# Altium schematic sheet MCU.SchDoc(MCU)
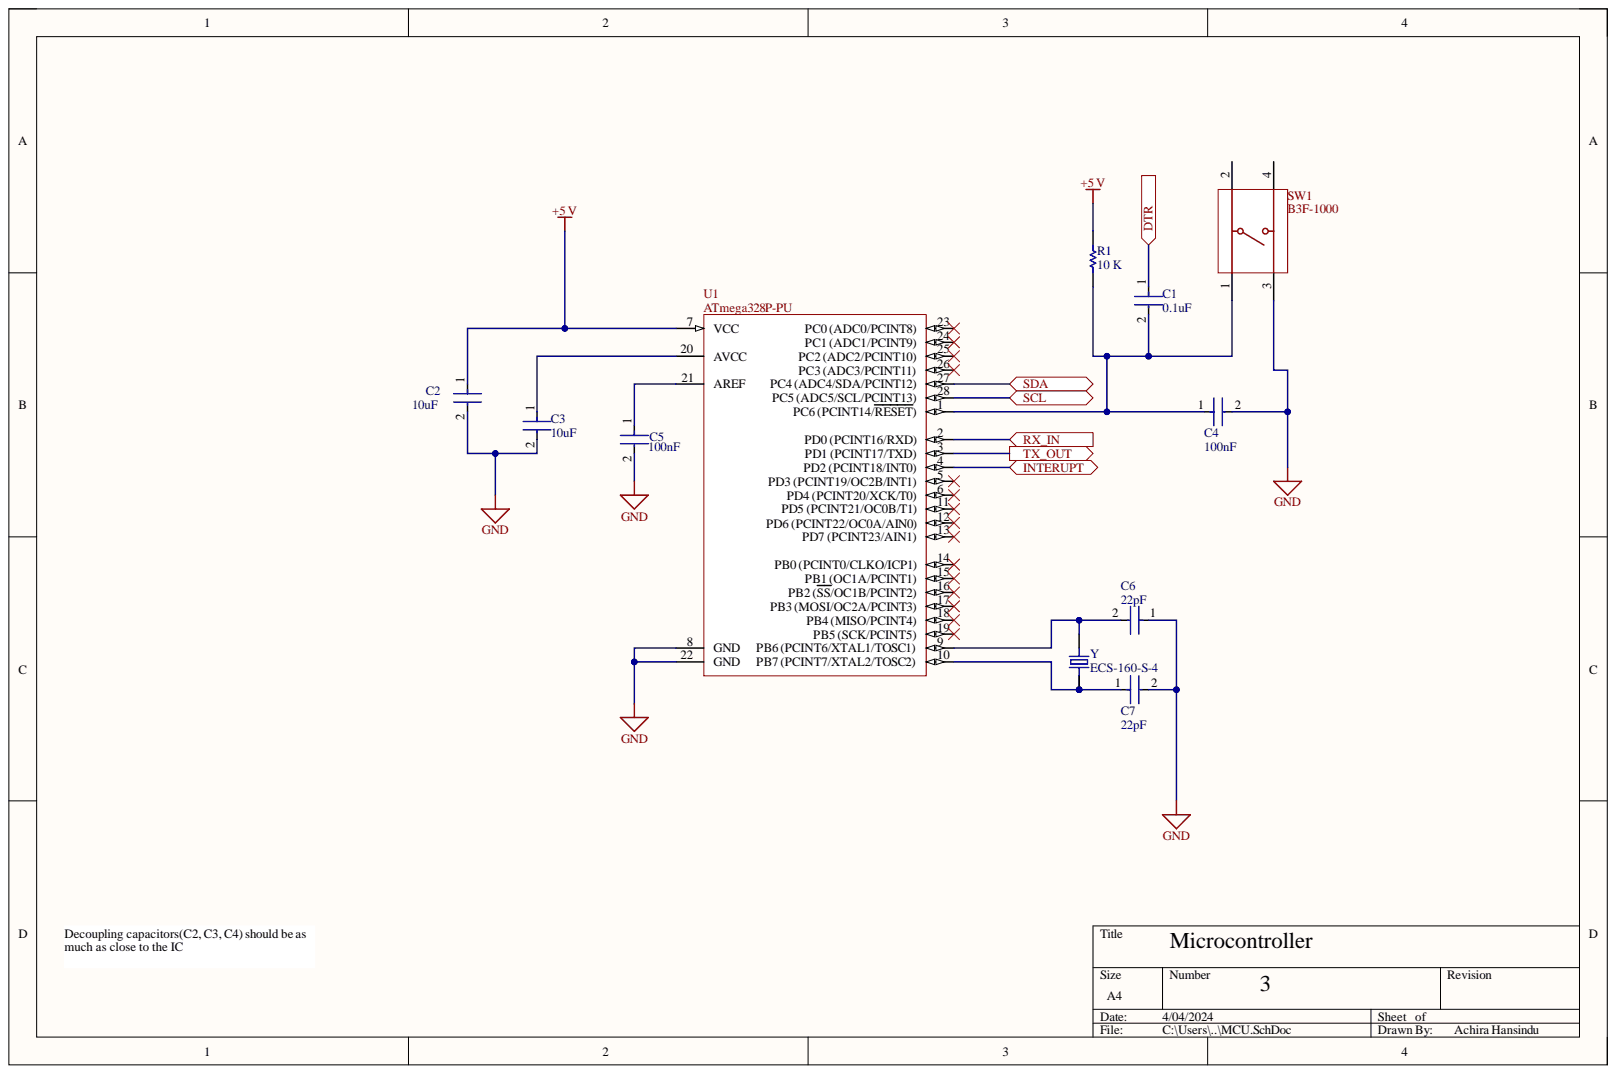
[diagram p0: MCU.SchDoc]

## Parts
C1  Capacitance: 0.1uF  [FP-GRM31M-0_15-IPC_A]  (hidden comment: GRM31M1X1H104JA01L)
C2  Capacitance: 10uF  [FP-C1206C106K4PACTU-MFG]  (hidden comment: C1206C106K4PACTU)
C3  Capacitance: 10uF  [FP-C1206C106K4PACTU-MFG]  (hidden comment: C1206C106K4PACTU)
C4  Capacitance: 100nF  [FP-CL640-IPC_C]  (hidden comment: CL05B104KO5NNWC)
C5  Capacitance: 100nF  [FP-CL640-IPC_C]  (hidden comment: CL05B104KO5NNWC)
C6  value 22pF  Capacitance: 22pF  [FP-GRM31A-0_2-e0_3_0_8-IPC_B]  (hidden comment: GRM31A7U3D220JW31D)
C7  Capacitance: 22pF  [FP-GRM31A-0_2-e0_3_0_8-IPC_B]  (hidden comment: GRM31A7U3D220JW31D)
R1  value 10 K  [RESC3216X70X50LL20T25]  (hidden comment: ERA8AEB103V)
SW1  B3F-1000  [OMRON-B3F-1000-4_V]
U1  ATmega328P-PU  [28P3]
Y  ECS-160-S-4  [XTAL_ECS-160-20-4X]

## Ports
DTR, INTERUPT, RX_IN, SCL, SDA, TX_OUT

## Nets
+5 V: C2.1 R1.2 U1.7(VCC)
GND: C2.2 C3.2 C4.2 C5.2 C6.1 C7.2 SW1.3 U1.8(GND) U1.22(GND)
DTR: C1.1 ; port DTR
INTERUPT: U1.4(PD2_(PCINT18/INT0)) ; port INTERUPT
RX_IN: U1.2(PD0_(PCINT16/RXD)) ; port RX_IN
SCL: U1.28(PC5_(ADC5/SCL/PCINT13)) ; port SCL
SDA: U1.27 ; port SDA
TX_OUT: U1.3(PD1_(PCINT17/TXD)) ; port TX_OUT
NetC1_2: C1.2 C4.1 R1.1 SW1.1 U1.1(PC6_(PCINT14/RESET))
NetC3_1: C3.1 U1.20(AVCC)
NetC5_1: C5.1 U1.21(AREF)
NetC6_2: C6.2 U1.9 Y.2
NetC7_1: C7.1 U1.10 Y.1
NetSW1_2: SW1.2
NetSW1_4: SW1.4
NetU1_5: U1.5(PD3_(PCINT19/OC2B/INT1))
NetU1_6: U1.6(PD4_(PCINT20/XCK/T0))
NetU1_11: U1.11(PD5_(PCINT21/OC0B/T1))
NetU1_12: U1.12(PD6_(PCINT22/OC0A/AIN0))
NetU1_13: U1.13(PD7_(PCINT23/AIN1))
NetU1_14: U1.14(PB0_(PCINT0/CLKO/ICP1))
NetU1_15: U1.15(PB1_(OC1A/PCINT1))
NetU1_16: U1.16(PB2_(SS/OC1B/PCINT2))
NetU1_17: U1.17(PB3_(MOSI/OC2A/PCINT3))
NetU1_18: U1.18(PB4_(MISO/PCINT4))
NetU1_19: U1.19(PB5_(SCK/PCINT5))
NetU1_23: U1.23(PC0_(ADC0/PCINT8))
NetU1_24: U1.24(PC1_(ADC1/PCINT9))
NetU1_25: U1.25
NetU1_26: U1.26(PC3_(ADC3/PCINT11))

## Library data of the parts
C1 = CMP-06035-007547-1: Chip Multilayer Ceramic Capacitors for General Purpose, 1206, 0.10uF, SL, +350/-1000ppm/°C, 5%, 50V; Dissipation Factor: 0.025%; Case Code (Imperial): 1206; Case Code (Metric): 3216; Case/Package: 1206; Component Kind: Standard; Depth: 1.6mm; Dielectric Material: Ceramic; Height: 1.15mm; Length: 0.126inch; Library Name: Altium Content Vault; Max Operating Temperature: 125°C; Min Operating Temperature: -55°C; Mount: Surface Mount; Packaging: Tape and Reel; Temperature Coefficient: -1000ppm/°C; Termination: SMD/SMT; Thickness: 0.049inch; Tolerance: 5%; Voltage: 50V; Voltage Rating: 50V; Voltage Rating (DC): 50V; Width: 0.063inch
C2 C3 = CMP-03020-034688-1: CAP CER 10UF 16V X5R 1206; Dielectric Material: Ceramic; Tolerance: 10%; Case/Package: 1206; Component Kind: Standard; Construction: Flat; Depth: 1.6mm; Dielectric: X5R; Height: 1.6mm; Lead Free: Lead Free; Length: 3.2mm; Library Name: Altium Content Vault; Max Operating Temperature: 85°C; Min Operating Temperature: -55°C; Mount: Surface Mount; Packaging: Tape and Reel; Radiation Hardening: No; RoHS Compliant: Yes; Termination: SMD/SMT; Voltage: 16V; Voltage Rating (DC): 16V
C4 C5 = CMP-13271-001008-1: Cap Ceramic 100nF 16V X7R ±10% SMD 0402 +125°C Paper T/R; Case Code (Imperial): 0402; Case Code (Metric): 1005; Case/Package: 0402; Component Kind: Standard; Contact Plating: Tin; Dielectric: X7R; Length: 0.039inch; Library Name: Altium Content Vault; Max Operating Temperature: 125°C; Min Operating Temperature: -55°C; Mount: Surface Mount; Package Quantity: 1; Packaging: Cut Tape; Termination: Wraparound; Thickness: 0.022inch; Tolerance: 10%; Voltage Rating: 16V; Width: 0.02inch
C6 C7 = CMP-06035-015250-1: Chip Multilayer Ceramic Capacitors for General Purpose, 1206, 22pF, U2J, -750ppm/°C, 5%, 2kV; Case Code (Imperial): 1206; Case Code (Metric): 3216; Case/Package: 1206; Component Kind: Standard; Depth: 1.6mm; Dielectric: U2J; Height: 1mm; Length: 3.2mm; Library Name: Altium Content Vault; Material: Ceramic; Max Operating Temperature: 125°C; Min Operating Temperature: -55°C; Mount: Surface Mount; Package Quantity: 4000; Packaging: Tape and Reel; Radiation Hardening: No; Termination: SMD/SMT; Thickness: 0.039inch; Tolerance: 5%; Voltage Rating: 2kV; Voltage Rating (DC): 2kV; Weight: 0.000571oz; Width: 1.6mm
R1 = CMP-2003-04407-1: Manufacturer: Panasonic; Component Kind: Standard; Library Name: Altium Content Vault; Part Number: ERA8AEB103V
SW1 = CMP-1677-00002-1: Tactile Switch, SPST-NO, 0.05 A, 5 to 24 V, -25 to 70 degC, 4-Pin THD, RoHS, Bulk; Capacitance: -45 f; Current Rating: 1.5 A; Gender: Female; Shielding: Shielded; Voltage Rating: 150 V; Component Kind: Standard; ComponentLink1Description: Manufacturer URL; ComponentLink1URL: http://www.omron.com/; ComponentLink2Description: Datasheet; ComponentLink2URL: http://www.omron.com/ecb/products/pdf/en-b3f.pdf; Contact Material: Brass; Contact Plating: Gold; DatasheetVersion: Jan-15; Height: 14.99 mm; Lead Pitch: 1.27 mm; Length: 18.34 mm; Library Name: Altium Content Vault; Max Operating Temperature: 70 degC; Min Operating Temperature: -40 degC; Mount: Through Hole; Package Quantity: 1080; PackageDescription: 4-Pin Through Hole Device, Body 6 x 6 mm; PackageReference: B3F-1000; Packaging: Tray; Pins: 8; Radiation Hardening: No; RoHSCompliant: true; Width: 25.4 mm
U1 = CMP-0095-00013-2: 8-bit AVR Microcontroller, 32KB Flash, 1KB EEPROM, 2KB SRAM, 28-pin PDIP, Industrial Grade (-40°C to 85°C); Component Kind: Standard; ComponentLink1Description: Manufacturer URL; ComponentLink1URL: http://www.atmel.com/; ComponentLink2Description: ATmega48A/48PA/88A/88PA/168A/168PA/328/328P Datasheet; ComponentLink2URL: http://www.atmel.com/dyn/resources/prod_documents/8271.pdf; ComponentLink3Description: ATmega48A/48PA/88A/88PA/168A/168PA/328/328P Summary; ComponentLink3URL: http://www.atmel.com/dyn/resources/prod_documents/8271S.pdf; DatasheetVersion: revD, May-2011; Library Name: Altium Content Vault; PackageDescription: 28-lead (0.300"/7.62mm Wide) Plastic Dual Inline Package (PDIP); PackageReference: 28P3; PackageVersion: revB, Sep-2001; RevisionNote: DatasheetVersion updated. URLs added.
Y = ECS-160-S-4: 16MHz Â±30ppm Crystal Series 40 Ohms HC-49/US; MF: ECS Inc.; Availability: Unavailable; Component Kind: Standard; Library Name: ECS-160-S-4.IntLib; MP: ECS-160-S-4; Package: HC49/US ECS International; Price: None; SnapEDA_Link: https://www.snapeda.com/parts/ECS-160-S-4/ECS%20Inc./view-part/3657575/?ref=snap
